# Altium schematic sheet MCU.SchDoc
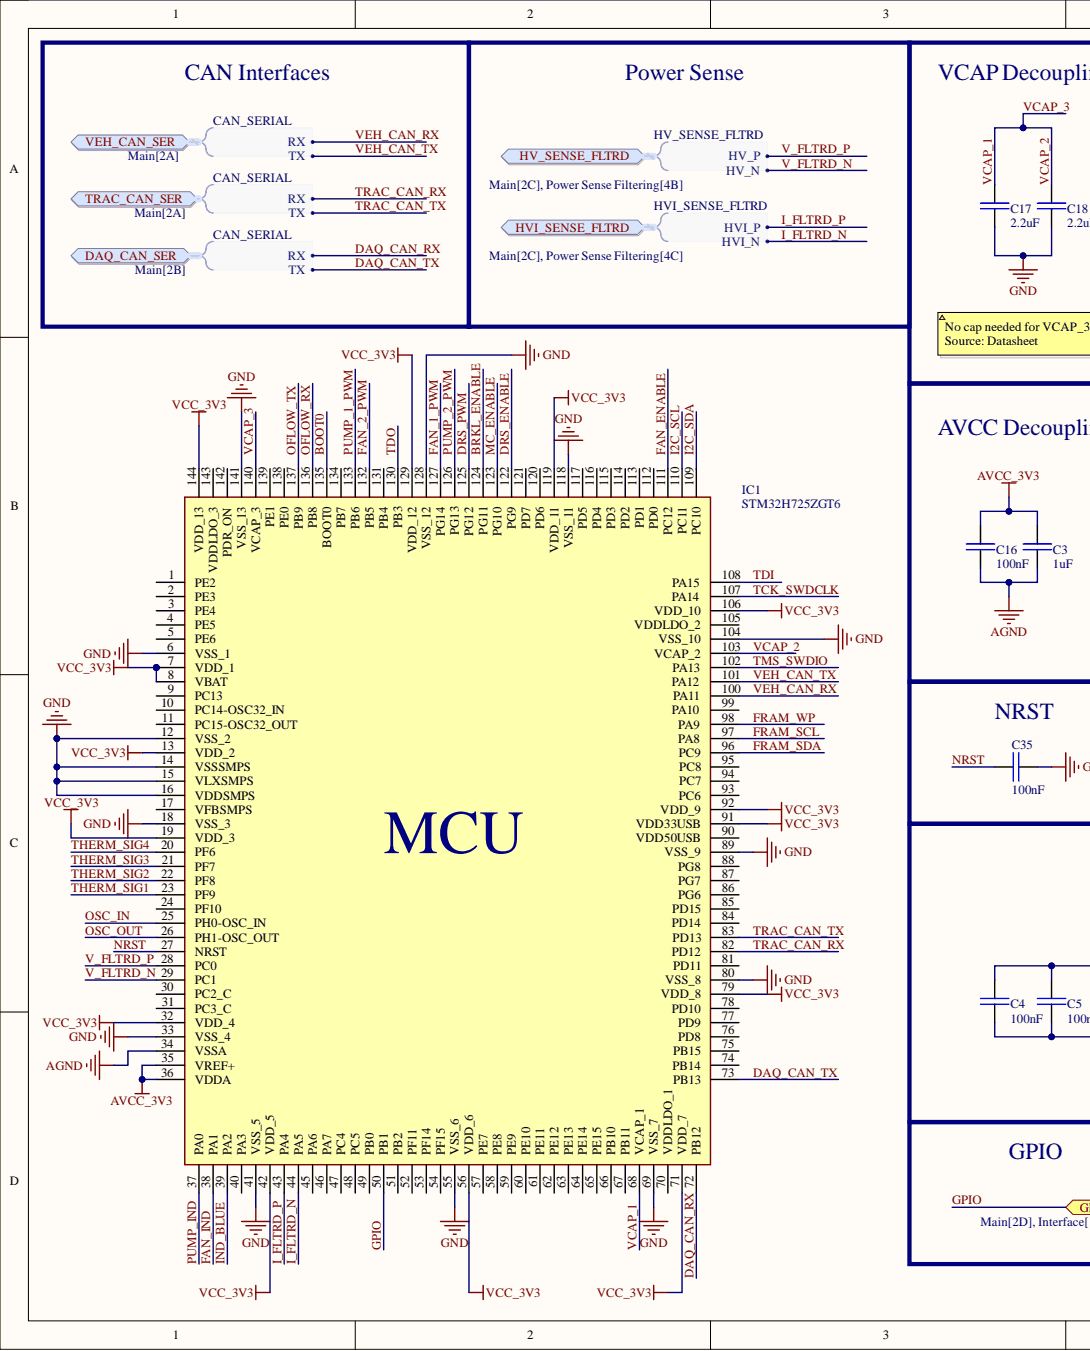
[diagram p0: MCU.SchDoc, left]
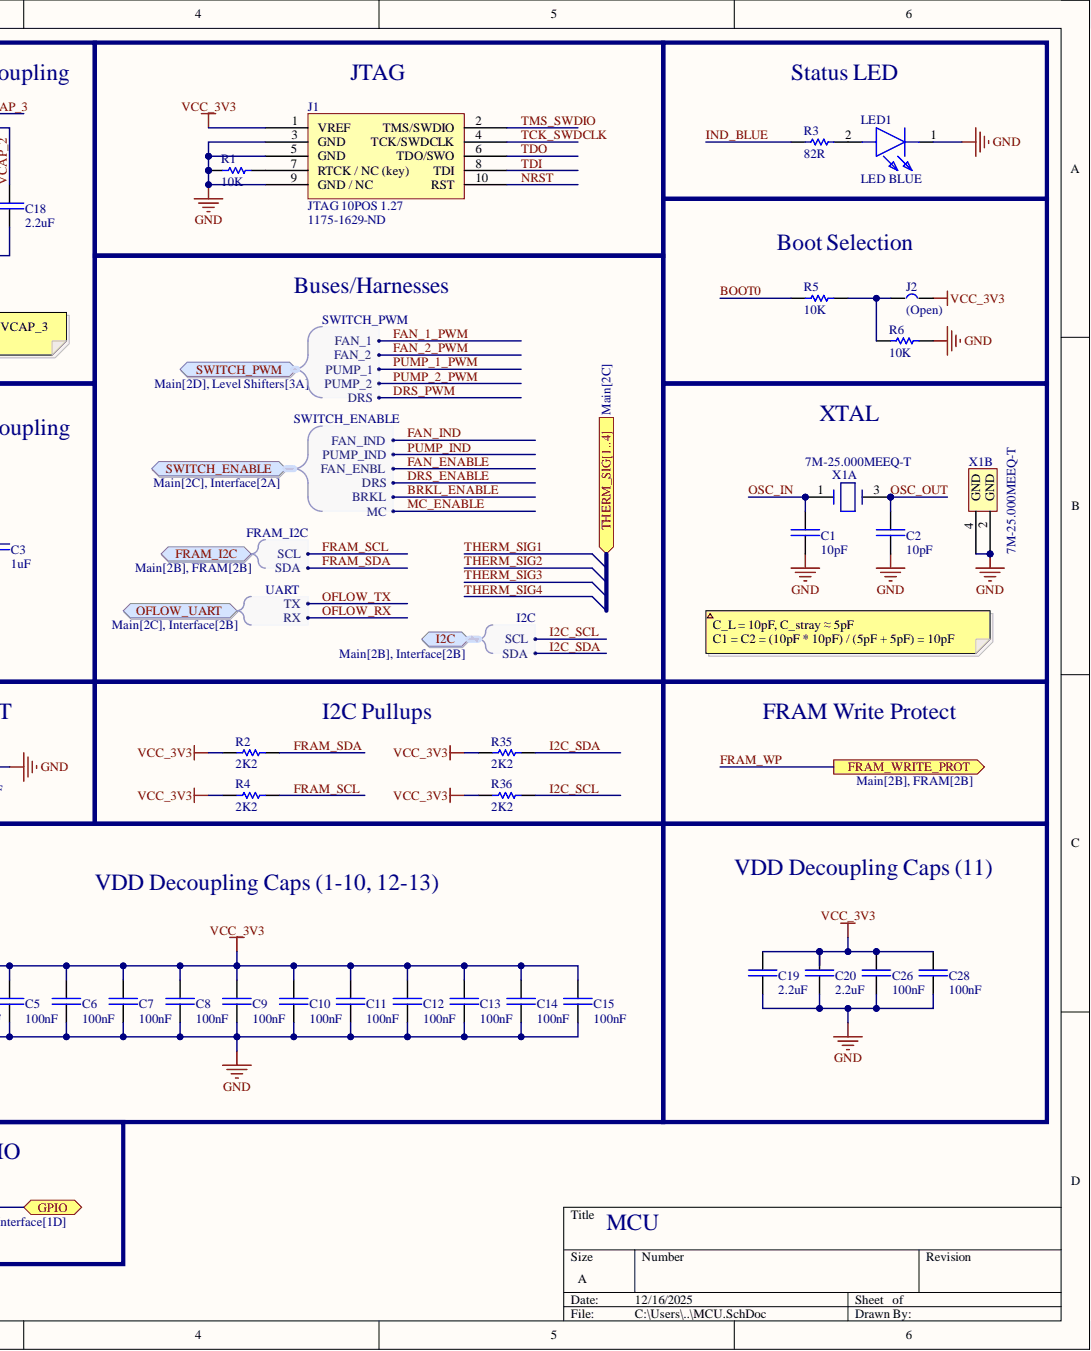
[diagram p1: MCU.SchDoc, right]

## Parts
C1  10pF  [CAP_SM0603_YAGEOCC2_N]
C2  10pF  [CAP_SM0603_YAGEOCC2_N]
C3  1uF  [CAP_SM0603_YAGEOCC2_N]
C4  100nF  [CAP_SM0603_YAGEOCC2_N]
C5  100nF  [CAP_SM0603_YAGEOCC2_N]
C6  100nF  [CAP_SM0603_YAGEOCC2_N]
C7  100nF  [CAP_SM0603_YAGEOCC2_N]
C8  100nF  [CAP_SM0603_YAGEOCC2_N]
C9  100nF  [CAP_SM0603_YAGEOCC2_N]
C10  100nF  [CAP_SM0603_YAGEOCC2_N]
C11  100nF  [CAP_SM0603_YAGEOCC2_N]
C12  100nF  [CAP_SM0603_YAGEOCC2_N]
C13  100nF  [CAP_SM0603_YAGEOCC2_N]
C14  100nF  [CAP_SM0603_YAGEOCC2_N]
C15  100nF  [CAP_SM0603_YAGEOCC2_N]
C16  100nF  [CAP_SM0603_YAGEOCC2_N]
C17  2.2uF  [CAP_SM0603_YAGEOCC2_N]
C18  2.2uF  [CAP_SM0603_YAGEOCC2_N]
C19  2.2uF  [CAP_SM0603_YAGEOCC2_N]
C20  2.2uF  [CAP_SM0603_YAGEOCC2_N]
C26  100nF  [CAP_SM0603_YAGEOCC2_N]
C28  100nF  [CAP_SM0603_YAGEOCC2_N]
C35  100nF  [CAP_SM0603_YAGEOCC2_N]
IC1  STM32H725ZGT6  [QFP50P2200X2200X160-144N]
J1  JTAG 10POS 1.27  Supplier Part Number 1: 1175-1629-ND  [5x2 JTAG SMD]
J2  (Open)  [Solder Jumper]  (jumper)
LED1  LED BLUE  [LBQ99G01P1R1351]
R1  10K  [RES_SM0603_YAGEORC_N]
R2  2K2  [RES_SM0603_YAGEORC_N]
R3  82R  [RES_SM0603_YAGEORC_N]
R4  2K2  [RES_SM0603_YAGEORC_N]
R5  10K  [RES_SM0603_YAGEORC_N]
R6  10K  [RES_SM0603_YAGEORC_N]
R35  2K2  [RES_SM0603_YAGEORC_N]
R36  2K2  [RES_SM0603_YAGEORC_N]
X1  7M-25.000MEEQ-T  [OSCSC320X250X100N]  (parts A,B)

## Ports
DAQ_CAN_SER, DAQ_CAN_SER.RX, DAQ_CAN_SER.TX, FRAM_I2C, FRAM_I2C.SCL, FRAM_I2C.SDA, FRAM_WRITE_PROT, GPIO, HV_SENSE_FLTRD, HV_SENSE_FLTRD.HV_N, HV_SENSE_FLTRD.HV_P, HVI_SENSE_FLTRD, HVI_SENSE_FLTRD.HVI_N, HVI_SENSE_FLTRD.HVI_P, I2C, I2C.SCL, I2C.SDA, OFLOW_UART, OFLOW_UART.RX, OFLOW_UART.TX, SWITCH_ENABLE, SWITCH_ENABLE.BRKL, SWITCH_ENABLE.DRS, SWITCH_ENABLE.FAN_ENBL, SWITCH_ENABLE.FAN_IND, SWITCH_ENABLE.MC, SWITCH_ENABLE.PUMP_IND, SWITCH_PWM, SWITCH_PWM.DRS, SWITCH_PWM.FAN_1, SWITCH_PWM.FAN_2, SWITCH_PWM.PUMP_1, SWITCH_PWM.PUMP_2, THERM_SIG1, THERM_SIG2, THERM_SIG3, THERM_SIG4, THERM_SIG[1..4], TRAC_CAN_SER, TRAC_CAN_SER.RX, TRAC_CAN_SER.TX, VEH_CAN_SER, VEH_CAN_SER.RX, VEH_CAN_SER.TX

## Nets
AGND: C3.2 C16.2 IC1.34(VSSA)
AVCC_3V3: C3.1 C16.1 IC1.35(VREF+) IC1.36(VDDA)
GND: C1.2 C2.2 C4.2 C5.2 C6.2 C7.2 C8.2 C9.2 C10.2 C11.2 C12.2 C13.2 C14.2 C15.2 C17.2 C18.2 C19.2 C20.2 C26.2 C28.2 C35.1 IC1.6(VSS_1) IC1.12(VSS_2) IC1.14(VSSSMPS) IC1.15(VLXSMPS) IC1.16(VDDSMPS) IC1.18(VSS_3) IC1.33(VSS_4) IC1.41(VSS_5) IC1.55(VSS_6) IC1.69(VSS_7) IC1.80(VSS_8) IC1.89(VSS_9) IC1.104(VSS_10) IC1.118(VSS_11) IC1.128(VSS_12) IC1.141(VSS_13) J1.3(GND) J1.5(GND) J1.9(GND_/_NC) LED1.1 R1.1 R6.2 X1.2(GND) X1.4(GND)
VCC_3V3: C4.1 C5.1 C6.1 C7.1 C8.1 C9.1 C10.1 C11.1 C12.1 C13.1 C14.1 C15.1 C19.1 C20.1 C26.1 C28.1 IC1.7(VDD_1) IC1.8(VBAT) IC1.13(VDD_2) IC1.19(VDD_3) IC1.32(VDD_4) IC1.42(VDD_5) IC1.56(VDD_6) IC1.71(VDD_7) IC1.79(VDD_8) IC1.91(VDD33USB) IC1.92(VDD_9) IC1.106(VDD_10) IC1.119(VDD_11) IC1.129(VDD_12) IC1.144(VDD_13) J1.1(VREF) J2.2 R2.1 R4.1 R35.1 R36.1
BOOT0: IC1.135(BOOT0) R5.1
BRKL_ENABLE: IC1.124(PG11) ; port SWITCH_ENABLE
DAQ_CAN_RX: IC1.72(PB12) ; port DAQ_CAN_SER
DAQ_CAN_TX: IC1.73(PB13) ; port DAQ_CAN_SER
DRS_ENABLE: IC1.122(PG9) ; port SWITCH_ENABLE
DRS_PWM: IC1.125(PG12) ; port SWITCH_PWM
FAN_1_PWM: IC1.127(PG14) ; port SWITCH_PWM
FAN_2_PWM: IC1.132(PB5) ; port SWITCH_PWM
FAN_ENABLE: IC1.111(PC12) ; port SWITCH_ENABLE
FAN_IND: IC1.38(PA1) ; port SWITCH_ENABLE
FRAM_SCL: IC1.97(PA8) R4.2 ; port FRAM_I2C
FRAM_SDA: IC1.96(PC9) R2.2 ; port FRAM_I2C
FRAM_WP: IC1.98(PA9) ; port FRAM_WRITE_PROT
GPIO: IC1.50(PB1) ; port GPIO
I2C_SCL: IC1.110(PC11) R36.2 ; port I2C
I2C_SDA: IC1.109(PC10) R35.2 ; port I2C
I_FLTRD_N: IC1.44(PA5) ; port HVI_SENSE_FLTRD
I_FLTRD_P: IC1.43(PA4) ; port HVI_SENSE_FLTRD
IND_BLUE: IC1.39(PA2) R3.1
MC_ENABLE: IC1.123(PG10) ; port SWITCH_ENABLE
NRST: C35.2 IC1.27(NRST) J1.10(RST)
OFLOW_RX: IC1.136(PB8) ; port OFLOW_UART
OFLOW_TX: IC1.137(PB9) ; port OFLOW_UART
OSC_IN: C1.1 IC1.25(PH0-OSC_IN) X1.1
OSC_OUT: C2.1 IC1.26(PH1-OSC_OUT) X1.3
PUMP_1_PWM: IC1.133(PB6) ; port SWITCH_PWM
PUMP_2_PWM: IC1.126(PG13) ; port SWITCH_PWM
PUMP_IND: IC1.37(PA0) ; port SWITCH_ENABLE
TCK_SWDCLK: IC1.107(PA14) J1.4(TCK/SWDCLK)
TDI: IC1.108(PA15) J1.8(TDI)
TDO: IC1.130(PB3) J1.6(TDO/SWO)
THERM_SIG1: IC1.23(PF9) ; port THERM_SIG[1..4]  [also labelled ]
THERM_SIG2: IC1.22(PF8) ; port THERM_SIG[1..4]  [also labelled ]
THERM_SIG3: IC1.21(PF7) ; port THERM_SIG[1..4]  [also labelled ]
THERM_SIG4: IC1.20(PF6) ; port THERM_SIG[1..4]  [also labelled ]
TMS_SWDIO: IC1.102(PA13) J1.2(TMS/SWDIO)
TRAC_CAN_RX: IC1.82(PD12) ; port TRAC_CAN_SER
TRAC_CAN_TX: IC1.83(PD13) ; port TRAC_CAN_SER
V_FLTRD_N: IC1.29(PC1) ; port HV_SENSE_FLTRD
V_FLTRD_P: IC1.28(PC0) ; port HV_SENSE_FLTRD
VCAP_1: C17.1 C18.1 IC1.68(VCAP_1) IC1.103(VCAP_2) IC1.140(VCAP_3)
VEH_CAN_RX: IC1.100(PA11) ; port VEH_CAN_SER
VEH_CAN_TX: IC1.101(PA12) ; port VEH_CAN_SER
NetIC1_1: IC1.1(PE2)
NetIC1_2: IC1.2(PE3)
NetIC1_3: IC1.3(PE4)
NetIC1_4: IC1.4(PE5)
NetIC1_5: IC1.5(PE6)
NetIC1_9: IC1.9(PC13)
NetIC1_10: IC1.10(PC14-OSC32_IN)
NetIC1_11: IC1.11(PC15-OSC32_OUT)
NetIC1_17: IC1.17(VFBSMPS)
NetIC1_24: IC1.24(PF10)
NetIC1_30: IC1.30(PC2_C)
NetIC1_31: IC1.31(PC3_C)
NetIC1_40: IC1.40(PA3)
NetIC1_45: IC1.45(PA6)
NetIC1_46: IC1.46(PA7)
NetIC1_47: IC1.47(PC4)
NetIC1_48: IC1.48(PC5)
NetIC1_49: IC1.49(PB0)
NetIC1_51: IC1.51(PB2)
NetIC1_52: IC1.52(PF11)
NetIC1_53: IC1.53(PF14)
NetIC1_54: IC1.54(PF15)
NetIC1_57: IC1.57(PE7)
NetIC1_58: IC1.58(PE8)
NetIC1_59: IC1.59(PE9)
NetIC1_60: IC1.60(PE10)
NetIC1_61: IC1.61(PE11)
NetIC1_62: IC1.62(PE12)
NetIC1_63: IC1.63(PE13)
NetIC1_64: IC1.64(PE14)
NetIC1_65: IC1.65(PE15)
NetIC1_66: IC1.66(PB10)
NetIC1_67: IC1.67(PB11)
NetIC1_70: IC1.70(VDDLDO_1)
NetIC1_74: IC1.74(PB14)
NetIC1_75: IC1.75(PB15)
NetIC1_76: IC1.76(PD8)
NetIC1_77: IC1.77(PD9)
NetIC1_78: IC1.78(PD10)
NetIC1_81: IC1.81(PD11)
NetIC1_84: IC1.84(PD14)
NetIC1_85: IC1.85(PD15)
NetIC1_86: IC1.86(PG6)
NetIC1_87: IC1.87(PG7)
NetIC1_88: IC1.88(PG8)
NetIC1_90: IC1.90(VDD50USB)
NetIC1_93: IC1.93(PC6)
NetIC1_94: IC1.94(PC7)
NetIC1_95: IC1.95(PC8)
NetIC1_99: IC1.99(PA10)
NetIC1_105: IC1.105(VDDLDO_2)
NetIC1_112: IC1.112(PD0)
NetIC1_113: IC1.113(PD1)
NetIC1_114: IC1.114(PD2)
NetIC1_115: IC1.115(PD3)
NetIC1_116: IC1.116(PD4)
NetIC1_117: IC1.117(PD5)
NetIC1_120: IC1.120(PD6)
NetIC1_121: IC1.121(PD7)
NetIC1_131: IC1.131(PB4)
NetIC1_134: IC1.134(PB7)
NetIC1_138: IC1.138(PE0)
NetIC1_139: IC1.139(PE1)
NetIC1_142: IC1.142(PDR_ON)
NetIC1_143: IC1.143(VDDLDO_3)
NetJ1_7: J1.7 R1.2
NetJ2_1: J2.1 R5.2 R6.1
NetLED1_2: LED1.2 R3.2

## Library data of the parts
C1 C2 = CC0603JRNPO9BN100: CAP 10pF SM0603 NPO 50V YAGEO CC2; Tolerance (%): 5; Voltage Rating (Volts): 50; Category: Capacitor; Component Kind: Standard; ComponentLink1Description: Manufacturer Website; ComponentLink1URL: http://www.yageo.com; Library Name: ResistorCapsInductors.IntLib; Manufacturer: Yageo; Manufacturer Part Number: CC0603JRNPO9BN100; Package: 0603; Supplier 1: Farnell; Supplier 2: Digi-Key; Supplier Part Number 1: 721967; Supplier Part Number 2: 311-1058-1-ND; Value: 10pF
C3 = GMK107AB7105KAHT: Ceramic Capacitor, Multilayer, Ceramic, 35V, 10% +Tol, 10% -Tol, X7R, 15% TC, 1uF, Surface Mount, 0603; Manufacturer: Taiyo Yuden; Multilayer: Yes; Reference Standard: AEC-Q200; Capacitor Type: Ceramic; Case/Package: 0603; Category: Ceramic Capacitors; Component Kind: Standard; ComponentLink1Description: https://datasheet.ciiva.com:443/pdfs/VipMasterIC/IC/TAIY/TAIY-S-A0009098652/TAIY-S-A0009097944-1.pdf?src-supplier=IHS+Markit; ComponentLink1URL: https://datasheet.ciiva.com:443/pdfs/VipMasterIC/IC/TAIY/TAIY-S-A0009098652/TAIY-S-A0009097944-1.pdf?src-supplier=IHS+Markit; ComponentLink2Description: https://datasheet.ciiva.com/18697/mlcc01-hq-e-18697493.pdf?src-supplier=Digikey; ComponentLink2URL: https://datasheet.ciiva.com/18697/mlcc01-hq-e-18697493.pdf?src-supplier=Digikey; ComponentLink3Description: https://datasheet.ciiva.com/18697/mlcc01-hq-e-18697494.pdf?src-supplier=Digikey; ComponentLink3URL: https://datasheet.ciiva.com/18697/mlcc01-hq-e-18697494.pdf?src-supplier=Digikey; Dielectric Material: Ceramic; Height: 0.8mm; Length: 1.6mm; Library Name: ResistorCapsInductors.IntLib; Manufacturer Part Number: GMK107AB7105KAHT; Max Operating Temperature: 125°C; Min Operating Temperature: -55°C; Mounting Technology: Surface Mount; Package Shape: Rectangular; Package Style: SMTMeter; Packaging: Tape and Reel; Pins: 2; Pricing: 1=0.13, 10=0.073, 100=0.044, 1000=0.02931 (USD); Rated DC Voltage (URdc): 35V; Reach SVHC Compliant: Yes; RoHS: Yes; RoHS Compliant: Yes; Stock: 95004; Supplier: Digikey; Supplier 1: DigiKey; Supplier Part Number: 587-3352-1-ND; Supplier Part Number 1: 587-3352-6-ND; Temperature Characteristics Code: X7R; Termination: Wraparound; Tolerance  (fill in as +-): 10%; Tolerance (fill in as +-): 10%; Value: 1uF; Width: 0.8mm
C4-C16 C26 C28 C35 = CC0603KRX7R9BB104: CAP 100nF SM0603 X7R 50V YAGEO CC2; Tolerance (%): 10; Voltage Rating (Volts): 50; Category: Capacitor; Component Kind: Standard; ComponentLink1Description: Manufacturer Website; ComponentLink1URL: http://www.yageo.com; Library Name: ResistorCapsInductors.IntLib; Manufacturer: Yageo; Manufacturer Part Number: CC0603KRX7R9BB104; Package: 0603; Supplier 1: Farnell; Supplier 2: Digi-Key; Supplier Part Number 1: 1362556; Supplier Part Number 2: 311-1344-1-ND; Value: 100nF
C17-C20 = CC0603KRX5R8BB225: CAP CER 2.2UF 25V X5R 0603; Manufacturer: Yageo; Manufacturer Part Number: CC0603KRX7R9BB183; Tolerance (%): 10; Voltage Rating (Volts): 50; Category: Capacitor; Component Kind: Standard; ComponentLink1Description: Manufacturer Website; ComponentLink1URL: http://www.yageo.com; Library Name: ResistorCapsInductors.IntLib; Package: 0603; Supplier 1: Farnell; Supplier 2: Digi-Key; Supplier Part Number 1: 3019743; Value: 2.2uF
IC1 = STM32H725ZGT6: H7 MCU; Manufacturer_Name: STMicroelectronics; Arrow Part Number: STM32H725ZGT6; Arrow Price/Stock: https://www.arrow.com/en/products/stm32h725zgt6/stmicroelectronics?region=nac; Component Kind: Standard; Datasheet Link: https://www.st.com/resource/en/datasheet/stm32h725ag.pdf; Height: 1.6mm; Library Name: Misc.IntLib; Manufacturer_Part_Number: STM32H725ZGT6; Mouser Part Number: 511-STM32H725ZGT6; Mouser Price/Stock: https://www.mouser.co.uk/ProductDetail/STMicroelectronics/STM32H725ZGT6?qs=sPbYRqrBIVkxUSEHgllpOw%3D%3D; Supplier 1: DigiKey; Supplier Part Number 1: 497-STM32H725ZGT6-ND
J1 = CNC Tech 3220-10-0300-00: JTAG; Component Kind: Standard; Library Name: Connectors.IntLib; Supplier 1: Digi-Key
J2 = Jumper: Description: Jumper; Library Name: Misc.IntLib
LED1 = LB Q99G.01-P1R1-35-1-5-R18: LED Blue 2.85V 5mA USE THIS ONE; Manufacturer_Name: ams OSRAM; Component Kind: Standard; Datasheet Link: https://look.ams-osram.com/m/5d39d28026a1abba/original/LB-Q99G-01.pdf; Height: 0.75mm; Library Name: DiodesAndFuses.IntLib; Manufacturer_Part_Number: LB Q99G.01-P1R1-35-1; Mouser Part Number: 720-LBQ99G01P1R135; Mouser Price/Stock: https://www.mouser.co.uk/ProductDetail/ams-OSRAM/LB-Q99G.01-P1R1-35-1?qs=IPgv5n7u5QZHWhEkNg3xgA%3D%3D; Supplier 1: DigiKey; Supplier Part Number 1: 475-LBQ99G.01-P1R1-35-1CT-ND
R1 R5 R6 = RC0603FR-0710KL: RES 10K SM0603 YAGEO RC_L; Power (Watts): 0.1; Resistance (Ohms): 10000; Tolerance (%): 1; Category: Resistor; Component Kind: Standard; ComponentLink1Description: Manufacturer Website; ComponentLink1URL: http://www.yageo.com; Library Name: ResistorCapsInductors.IntLib; Manufacturer: Yageo; Manufacturer Part Number: RC0603FR-0710KL; Package: 0603; Supplier 1: Farnell; Supplier 2: Digi-Key; Supplier Part Number 1: 9238603; Supplier Part Number 2: 311-10.0KHRCT-ND; Value: 10K
R2 R4 R35 R36 = RC0603FR-072K2L: RES 2K2 SM0603 YAGEO RC_L; Power (Watts): 0.1; Resistance (Ohms): 2200; Tolerance (%): 1; Category: Resistor; Component Kind: Standard; ComponentLink1Description: Manufacturer Website; ComponentLink1URL: http://www.yageo.com; Library Name: ResistorCapsInductors.IntLib; Manufacturer: Yageo; Manufacturer Part Number: RC0603FR-072K2L; Package: 0603; Supplier 1: Farnell; Supplier 2: Digi-Key; Supplier Part Number 1: 9238522; Supplier Part Number 2: 311-2.20KHRCT-ND; Value: 2K2
R3 = RC0603FR-0782RL: RES 82R SM0603 YAGEO RC_L; Power (Watts): 0.1; Resistance (Ohms): 82; Tolerance (%): 1; Category: Resistor; Component Kind: Standard; ComponentLink1Description: Manufacturer Website; ComponentLink1URL: http://www.yageo.com; Library Name: ResistorCapsInductors.IntLib; Manufacturer: Yageo; Manufacturer Part Number: RC0603FR-0782RL; Package: 0603; Supplier 1: Farnell; Supplier 2: Digi-Key; Supplier Part Number 1: 9238352; Supplier Part Number 2: 311-82.0HRCT-ND; Value: 82R
X1 = 7M-25.000MEEQ-T: CRYSTAL 25.0000MHZ 10PF SMD; Manufacturer 1: TXC; ESR (Equivalent Series Resistance): 60R; Frequency: 25MHz; Frequency Stability: 10ppm; Case/Package: SMD/SMT; Component Kind: Standard; ComponentLink1Description: https://datasheet.ciiva.com/26918/getdatasheetpartid-757227-26918816.pdf; ComponentLink1URL: https://datasheet.ciiva.com/26918/getdatasheetpartid-757227-26918816.pdf; ComponentLink2Description: https://datasheet.ciiva.com/856/7m-accuracy-856022.pdf; ComponentLink2URL: https://datasheet.ciiva.com/856/7m-accuracy-856022.pdf; ComponentLink3Description: https://datasheet.ciiva.com/22658/1794111-22658486.pdf; ComponentLink3URL: https://datasheet.ciiva.com/22658/1794111-22658486.pdf; ComponentLink4Description: https://datasheet.ciiva.com/856/7m-accuracy-856023.pdf; ComponentLink4URL: https://datasheet.ciiva.com/856/7m-accuracy-856023.pdf; ComponentLink5Description: https://datasheet.ciiva.com/856/7m-accuracy-856024.pdf; ComponentLink5URL: https://datasheet.ciiva.com/856/7m-accuracy-856024.pdf; ComponentLink6Description: https://datasheet.ciiva.com/4946/7m-11184-4946126.pdf; ComponentLink6URL: https://datasheet.ciiva.com/4946/7m-11184-4946126.pdf; ComponentLink7Description: https://datasheet.ciiva.com/28498/1794111-28498878.pdf; ComponentLink7URL: https://datasheet.ciiva.com/28498/1794111-28498878.pdf; Depth: 2.5mm; Frequency Tolerance: 10ppm; Height: 0.031inch; Length: 3.2mm; Library Name: Misc.IntLib; Load Capacitance: 10pF; Manufacturer Part Number 1: 7M-25.000MEEQ-T; Max Operating Temperature: 70°C; Min Operating Temperature: -20°C; Mount: Surface Mount; Number of Pins: 4; Package Quantity: 3000; Packaging: Tape and Reel; Pricing 1: 1=1.27, 10=1.209, 50=1.1812, 100=1.0125, 500=0.9844, 1000=0.8297 (USD); REACH SVHC: No SVHC; Stock 1: 17097; Supplier 1: Digi-Key; Supplier Part Number 1: 887-1326-1-ND; Termination: SMD/SMT; Tolerance: 10ppm; Width: 0.098inch
